# Altium schematic sheet lv_power_5V_cv.SchDoc
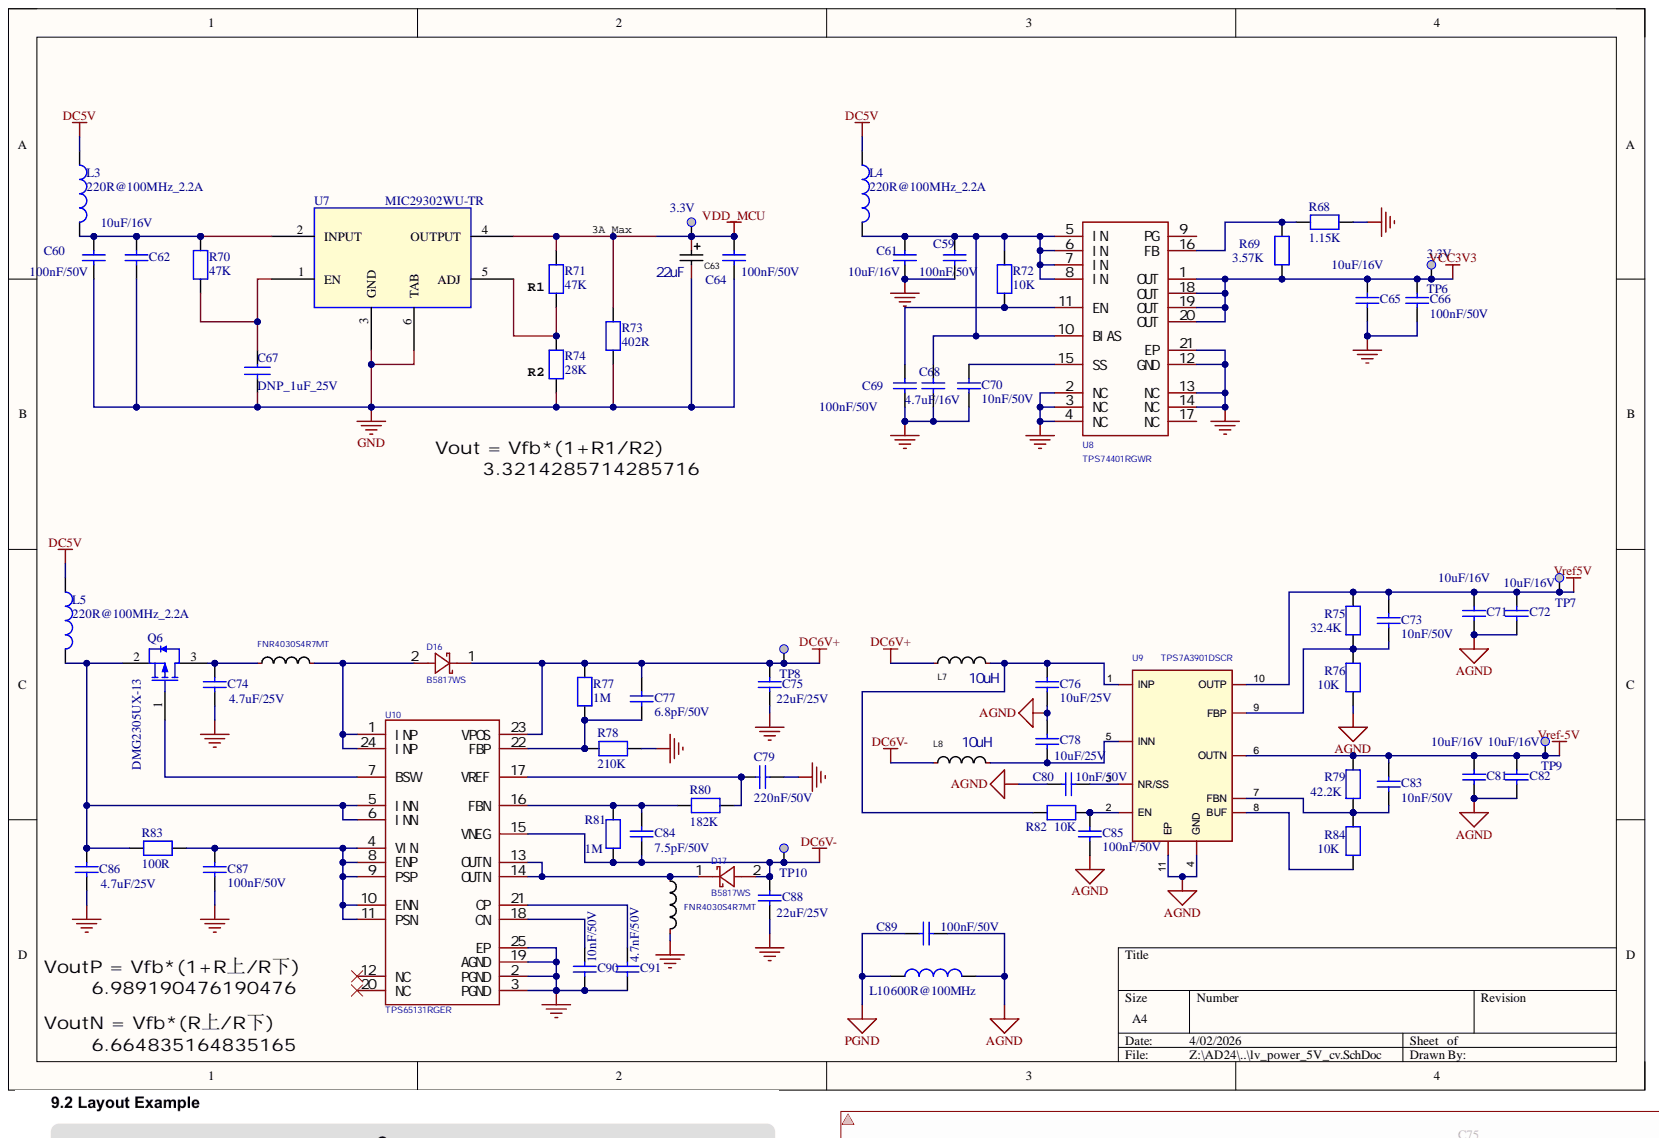
[diagram p0: lv_power_5V_cv.SchDoc]

## Parts
C59  100nF/50V  [C0402]
C60  100nF/50V  [C0402]
C61  10uF/16V  [C0805]
C62  10uF/16V  [C0805]
C63  22uF  [CAP-SMD_L6.0-W3.2-R-RD]
C64  100nF/50V  [C0402]
C65  10uF/16V  [C0805]
C66  100nF/50V  [C0402]
C67  DNP_1uF_25V  [C0603]
C68  4.7uF/16V  [C0603]
C69  100nF/50V  [C0402]
C70  10nF/50V  [C0402]
C71  10uF/16V  [C0805]
C72  10uF/16V  [C0805]
C73  10nF/50V  [C0402]
C74  4.7uF/25V  [C0603]
C75  22uF/25V  [C0805]
C76  10uF/25V  [C1206]
C77  6.8pF/50V  [C0402]
C78  10uF/25V  [C1206]
C79  220nF/50V  [C0402]
C80  10nF/50V  [C0402]
C81  10uF/16V  [C0805]
C82  10uF/16V  [C0805]
C83  10nF/50V  [C0402]
C84  7.5pF/50V  [C0402]
C85  100nF/50V  [C0402]
C86  4.7uF/25V  [C0603]
C87  100nF/50V  [C0402]
C88  22uF/25V  [C0805]
C89  100nF/50V  [C0402]
C90  10nF/50V  [C0402]
C91  4.7nF/50V  [C0402]
D16  B5817WS  [SOD-323_L1.7-W1.3-LS2.5-FD]
D17  B5817WS  [SOD-323_L1.7-W1.3-LS2.5-FD]
L3  220R@100MHz_2.2A  [L0603]
L4  220R@100MHz_2.2A  [L0603]
L5  220R@100MHz_2.2A  [L0603]
L6  FNR4030S4R7MT  [IND-SMD_L4.0-W4.0]
L7  10uH  [R0805]
L8  10uH  [R0805]
L9  FNR4030S4R7MT  [IND-SMD_L4.0-W4.0]
L10  600R@100MHz  [L0603]
Q6  DMG2305UX-13  [SOT-23(SOT-23-3)]
R68  1.15K  [R0402]
R69  3.57K  [R0402]
R70  47K  [R0402]
R71  47K  [R0402]
R72  10K  [R0402]
R73  402R  [0805]
R74  28K  [R0402]
R75  32.4K  [R0603]
R76  10K  [R0603]
R77  1M  [R0402]
R78  210K  [R0402]
R79  42.2K  [R0603]
R80  182K  [R0402]
R81  1M  [R0402]
R82  10K  [R0603]
R83  100R  [R0603]
R84  10K  [R0603]
TP5  3.3V  [TP_SMD_1MM]  (no BOM)
TP6  3.3V  [TP_SMD_1MM]  (no BOM)
TP7  +5.0V  [TP_SMD_1MM]  (value not drawn)  (no BOM)
TP8  +6V  [TP_SMD_1MM]  (value not drawn)  (no BOM)
TP9  -5.0V  [TP_SMD_1MM]  (value not drawn)  (no BOM)
TP10  -6V  [TP_SMD_1MM]  (value not drawn)  (no BOM)
U7  MIC29302WU-TR  [TO-263]
U8  TPS74401RGWR  [VQFN-20_L5.0-W5.0-P0.65-TL-EP]
U9  TPS7A3901DSCR  [WSON-10_L3.0-W3.0-P0.50-BL-EP]
U10  TPS65131RGER  [VQFN-24_L4.0-W4.0-P0.50-TL-EP]

## Nets
AGND: C71.1 C72.1 C76.2 C78.2 C80.1 C81.1 C82.1 C85.2 C89.2 L10.2 R76.1 U9.4(GND) U9.11(EP)
DC5V: L3.2 L4.2 L5.2
DC6V+: C75.2 C77.2 D16.1 L7.1 R77.2 TP8.1 U10.23(VPOS)
DC6V-: C84.2 C88.2 D17.2 L8.1 R81.2 TP10.1 U10.15(VNEG)
GND: C59.2 C60.2 C61.1 C62.1 C63.2 C64.2 C65.1 C66.2 C67.2 C68.1 C69.2 C70.2 C74.2 C75.1 C79.1 C86.2 C87.2 C88.1 C90.2 C91.2 L9.2 R68.1 R73.2 R74.2 R78.2 U7.3(GND) U7.6(TAB) U8.2(NC) U8.3(NC) U8.4(NC) U8.12(GND) U8.13(NC) U8.14(NC) U8.21(EP) U10.2(PGND) U10.3(PGND) U10.19(AGND) U10.25(EP)
PGND: C89.1 L10.1
VCC3V3: C65.2 C66.1 R69.1 TP6.1 U8.1(OUT) U8.18(OUT) U8.19(OUT) U8.20(OUT)
VDD_MCU: C63.1 C64.1 R71.1 R73.1 TP5.1 U7.4(OUTPUT)
Vref5V: C71.2 C72.2 C73.1 R75.1 TP7.1 U9.10(OUTP)
Vref-5V: C81.2 C82.2 C83.1 R79.1 TP9.1 U9.6(OUTN)
NetC59_1: C59.1 C61.2 C68.2 L4.1 R72.1 U8.5(IN) U8.6(IN) U8.7(IN) U8.8(IN) U8.10(BIAS)
NetC60_1: C60.1 C62.2 L3.1 R70.1 U7.2(INPUT)
NetC67_1: C67.1 R70.2 U7.1(EN)
NetC69_1: C69.1 R72.2 U8.11(EN)
NetC70_1: C70.1 U8.15(SS)
NetC73_2: C73.2 R75.2 R76.2 U9.9(FBP)
NetC74_1: C74.1 L6.1 Q6.3
NetC76_1: C76.1 L7.2 R82.1 U9.1(INP)
NetC77_1: C77.1 R77.1 R78.1 U10.22(FBP)
NetC78_1: C78.1 L8.2 U9.5(INN)
NetC79_2: C79.2 R80.1 U10.17(VREF)
NetC80_2: C80.2 U9.3(NR/SS)
NetC83_2: C83.2 R79.2 R84.2 U9.7(FBN)
NetC84_1: C84.1 R80.2 R81.1 U10.16(FBN)
NetC85_1: C85.1 R82.2 U9.2(EN)
NetC86_1: C86.1 L5.1 Q6.2 R83.1 U10.5(INN) U10.6(INN)
NetC87_1: C87.1 R83.2 U10.4(VIN) U10.8(ENP) U10.9(PSP) U10.10(ENN) U10.11(PSN)
NetC90_1: C90.1 U10.18(CN)
NetC91_1: C91.1 U10.21(CP)
NetD16_2: D16.2 L6.2 U10.1(INP) U10.24(INP)
NetD17_1: D17.1 L9.1 U10.13(OUTN) U10.14(OUTN)
NetQ6_1: Q6.1 U10.7(BSW)
NetR68_2: R68.2 R69.2 U8.16(FB)
NetR71_2: R71.2 R74.1 U7.5(ADJ)
NetR84_1: R84.1 U9.8(BUF)

Not connected: U8 9(PG) 17(NC); U10 12(NC) 20(NC)

## Library data of the parts
C59 C60 C64 C66 C69 C85 C87 C89 = Capacitor_2: 50V, X7R , 10%; Manufacturer: muRata; Manufacturer_Part_Number: GRM155R71H104KE14D; Component Kind: Standard; ComponentLink1Description: Datasheet; ComponentLink1URL: http://tunmcdsvn.tun.st.com/Component_Datasheet_Library/Trunk/Capacitors/Yageo_Multilayer_capacitor_X5R_series_100pF_to_100uF; Library Name: HiPin_Library_AD.IntLib
C61 C62 C65 C71 C72 C81 C82 = Capacitor_3: 16V, X7R, 10%; Manufacturer: YAGEO(国巨); Manufacturer_Part_Number: CC0805KKX7R7BB106; Component Kind: Standard; Library Name: HiPin_Library_AD.IntLib
C63 = TAJD107M020RNJ: 16V，±10%，1Ω@100kHz; Manufacturer: Kyocera AVX; Manufacturer Part: TAJC226K016RNJ; Component Kind: Standard; Library Name: HiPin_Library_AD.IntLib
C67 = CAP NP: DNP_1uF_25V_10%; Manufacturer Character: 中国大陆; As-Welded: DNP; Operation Temperature（℃）: -40~85℃; Component Kind: Standard; PCB Footprint: C0603
C68 = MCD-01121: 16V, X5R, 20%,; Manufacturer: MURATA; Manufacturer_Part_Number: GRM188R61C475KE11D; Database Table Name: MCD_PARTS$; Component Kind: Standard; ComponentLink1Description: Datasheet; ComponentLink1URL: http://tunmcdsvn.tun.st.com/Component_Datasheet_Library/Trunk/Capacitors/Murata_Capacitor_GR_series; Library Name: MCD_PARTS_database.DbLib
C70 C73 C80 C83 = Capacitor_2: 50V, X7R , 5%; Manufacturer: YAGEO; Manufacturer_Part_Number: CC0402JRX7R9BB103; Component Kind: Standard; ComponentLink1Description: Datasheet; ComponentLink1URL: http://tunmcdsvn.tun.st.com/Component_Datasheet_Library/Trunk/Capacitors/Yageo_Multilayer_capacitor_X5R_series_100pF_to_100uF; Library Name: HiPin_Library_AD.IntLib
C74 C86 = Capacitor_2: 25V, X5R , 10%; Manufacturer: muRata(村田); Manufacturer_Part_Number: GRM188R61E475KE11D; Component Kind: Standard; Library Name: HiPin_Library_AD.IntLib
C75 C88 = Capacitor_2: 25V, X5R, 10%; Internal_Part_Number: MCD-00014; Manufacturer: muRata; Manufacturer_Part_Number: GRM21BR61E226ME44L; Component Kind: Standard; ComponentLink1Description: Datasheet; ComponentLink1URL: http://tunmcdsvn.tun.st.com/Component_Datasheet_Library/Trunk/Capacitors/Yageo_Multilayer_capacitor_X5R_series_100pF_to_100uF; Library Name: HiPin_Library_AD.IntLib
C76 C78 = MCD-00097: 25V, X7R, 10%; Manufacturer: muRata(村田); Manufacturer_Part_Number: GRM31CR71E106KA12L; Database Table Name: MCD_PARTS$; Component Kind: Standard; Library Name: MCD_PARTS_database.DbLib; Status: Released
  C76: ComponentLink1Description: Datasheet; ComponentLink1URL: http://tunmcdsvn.tun.st.com/Component_Datasheet_Library/Trunk/Capacitors/Murata_Capacitor_GR_series
C77 = Capacitor_2: 50V,NP0; Manufacturer: YAGEO; Manufacturer_Part_Number: CC0402CRNPO9BN6R8; Component Kind: Standard; Library Name: HiPin_Library_AD.IntLib
C79 = Capacitor_2: 50V, X5R , 10%; Manufacturer: muRata; Manufacturer_Part_Number: GRM155R61H224KE01D; Component Kind: Standard; ComponentLink1Description: Datasheet; ComponentLink1URL: http://tunmcdsvn.tun.st.com/Component_Datasheet_Library/Trunk/Capacitors/Yageo_Multilayer_capacitor_X5R_series_100pF_to_100uF; Library Name: HiPin_Library_AD.IntLib
C84 = Capacitor_2: 50V, NP0; Manufacturer: YAGEO; Manufacturer_Part_Number: CC0402CRNPO9BN7R5; Component Kind: Standard; Library Name: HiPin_Library_AD.IntLib
C90 = Capacitor_2: 50V, X7R , 10%; Manufacturer: muRata; Manufacturer_Part_Number: GRM155R71H103KA88D; Component Kind: Standard; ComponentLink1Description: Datasheet; ComponentLink1URL: http://tunmcdsvn.tun.st.com/Component_Datasheet_Library/Trunk/Capacitors/Yageo_Multilayer_capacitor_X5R_series_100pF_to_100uF; Library Name: HiPin_Library_AD.IntLib
C91 = Capacitor_2: 50V, X7R , 10%; Manufacturer: muRata; Manufacturer_Part_Number: GCM155R71H472KA37D; Component Kind: Standard; ComponentLink1Description: Datasheet; ComponentLink1URL: http://tunmcdsvn.tun.st.com/Component_Datasheet_Library/Trunk/Capacitors/Yageo_Multilayer_capacitor_X5R_series_100pF_to_100uF; Library Name: HiPin_Library_AD.IntLib
D16 D17 = B5819WS_C7420331: 20V，450mV@1A; Component Kind: Standard; Device: B5817WS; Library Name: HiPin_Library_AD.IntLib; PCB3D: SOD-323_L1.7-W1.3-LS2.5-FD; Symbol: B5817WS
L3-L5 = MCD-00047: SMD Chip ferrite bead, 220R@100MHz +/-25%,2.2A, 50mohm; Manufacturer: Sunlord; Manufacturer_Part_Number: UPZ1608U221-2R2TF; Database Table Name: MCD_PARTS$; Component Kind: Standard; ComponentLink1Description: Datasheet; ComponentLink1URL: http://tunmcdsvn.tun.st.com/Component_Datasheet_Library/Trunk/Inductors/TaiTech_Bead_FCM1608_series; Internal_Part_Number: MCD-00047; Library Name: MCD_PARTS_database.DbLib
L6 L9 = LQH44PN1R0NP0L: 4.7uH ±20% 2.3A  DCR 78mΩ; Manufacturer: muRata(村田); Component Kind: Standard; Library Name: HiPin_Library_AD.IntLib; Manufacturer Part: FNR4030S4R7MT; PCB3D: IND-SMD_L4.0-W4.0-H1.9
L7 L8 = WPN252012H1R0MT: ±20% ,0.36A, 550mΩ; Manufacturer Part: SLM2012100MIT; Component Kind: Standard; Library Name: HiPin_Library_AD.IntLib
L10 = MCD-00047: +/-25%, 350mA, 500mohm DC; Manufacturer: TAI-TECH; Manufacturer_Part_Number: FCM1608KF-601T03; Database Table Name: MCD_PARTS$; Component Kind: Standard; ComponentLink1Description: Datasheet; ComponentLink1URL: http://tunmcdsvn.tun.st.com/Component_Datasheet_Library/Trunk/Inductors/TaiTech_Bead_FCM1608_series; Library Name: MCD_PARTS_database.DbLib
Q6 = AO3401A P道沟 X13A15 SOT-23: P沟道,-20V,-5A,40mΩ@-4.5V; Manufactu: DIODES(美台); Component Kind: Standard; Library Name: HiPin_Library_AD.IntLib; ManufacturerNumber: DMG2305UX-13; SuppliersPartNumber: C8549
R68-R84 = RES: 1%; Component Kind: Standard; Library Name: HiPin_Library_AD.IntLib
TP5-TP10 = MCD-00074: Test Point 1mm; Part_Type: Mechanical; Database Table Name: MCD_PARTS$; ComponentLink1Description: Datasheet; ComponentLink1URL: http://na; ECCN_EU: n/a; ECCN_US: n/a; Internal_Part_Number: MCD-00074; Library Name: MCD_PARTS_database.DbLib; Manufacturer: n/a; Manufacturer_Part_Number: n/a; Provided_By: n/a; Status: Released
U7 = MIC29302WU: LDO,Vin:26V,Iout:3A; Manufacturer: 广东华冠半导体有限公司（HGSEMI）; Manufacturer Character: 中国大陆; Manufacturer PartNumber: MIC29302S/TR; Operation Temperature（℃）: -40~125℃; Component Kind: Standard; PCB Footprint: TO-263; Temperature: -60~150℃
U8 = TPS74401RGWR: Manufacturer: TI(德州仪器); Add into BOM: yes; Dropout Voltage: 240mV@(3A); Maximum Input Voltage: 5.5V; Number of Outputs: 1; Output Current: 3A; Output Polarity: 正极; Output Type: 可调; Output Voltage: 800mV~3.6V; Power Supply Rejection Ratio (PSRR): 73dB; Component Kind: Standard; Convert to PCB: yes; Datasheet: https://www.ti.com/cn/lit/gpn/tps74401; Device: TPS74401RGWR; Feature: 防静电保护；浪涌保护；热保护(TSD)；欠压闭锁；过流保护(OCP)；带使能; JLCPCB Part Class: Extended Part; LCSC Part Name: 3A、低输入电压 (0.8V)、低噪声、高 PSRR、可调节超低压降稳压器; Library Name: HiPin_Library_AD.IntLib; Manufacturer Part: TPS74401RGWR; PCB3D: VQFN-20_L5.0-W5.0-H1.0-P0.65; Supplier: LCSC; Supplier Footprint: VQFN-20-EP(5x5); Supplier Part: C138728; Symbol: TPS74401RGWR
U9 = TPS7A3901DSCR: Manufacturer: TI(德州仪器); Add into BOM: yes; Maximum Input Voltage: 33V; Number of Outputs: 2; Output Current: 150mA; Output Polarity: 正极和负极; Output Type: 可调; Output Voltage: 1.2V~30V；0V~30V; Power Supply Rejection Ratio (PSRR): 56dB@(100KHz); Component Kind: Standard; Convert to PCB: yes; Datasheet: https://www.ti.com/cn/lit/gpn/tps7a39; Device: TPS7A3901DSCR; JLCPCB Part Class: Extended Part; LCSC Part Name: 150mA、33V、低噪声、高 PSRR、双通道、正负电压范围、低压降稳压器; Library Name: HiPin_Library_AD.IntLib; Manufacturer Part: TPS7A3901DSCR; Operating Temperature: -40℃~+125℃@(Tj); PCB3D: WSON-10_L3.0-W3.0-H1.0-P0.50; Supplier: LCSC; Supplier Footprint: WSON-10-EP(3x3); Supplier Part: C2685819; Symbol: TPS7A3901DSCR
U10 = TPS65130RGER: 双通道正负输出300mA双电源转换器; Manufacturer: TI(德州仪器); Add into BOM: yes; Frequency - Switching: 1.38MHz; Number of Outputs: 2; Output Type: 可调; Output Voltage: ±15V; Switch tube (built-in/external): 内置; Synchronous Rectifier: 否; Topology: 升压式；反相式; Voltage - Supply: 2.7V~5.5V; Component Kind: Standard; Convert to PCB: yes; Datasheet: https://www.ti.com/cn/lit/gpn/tps65130; Function: -; JLCPCB Part Class: Extended Part; Library Name: HiPin_Library_AD.IntLib; Manufacturer Part: TPS65131RGER; Operating Temperature: -40℃~+85℃@(TA); Output Current: -; PCB3D: VQFN-24_L4.0-W4.0-H1.0-P0.50; Quiescent Current: -; Supplier: LCSC; Supplier Footprint: VQFN-24-EP(4x4); Supplier Part: C54989
